# Altium schematic sheet 013_SoCAVInterface.SchDoc(013_SoCAVInterface)
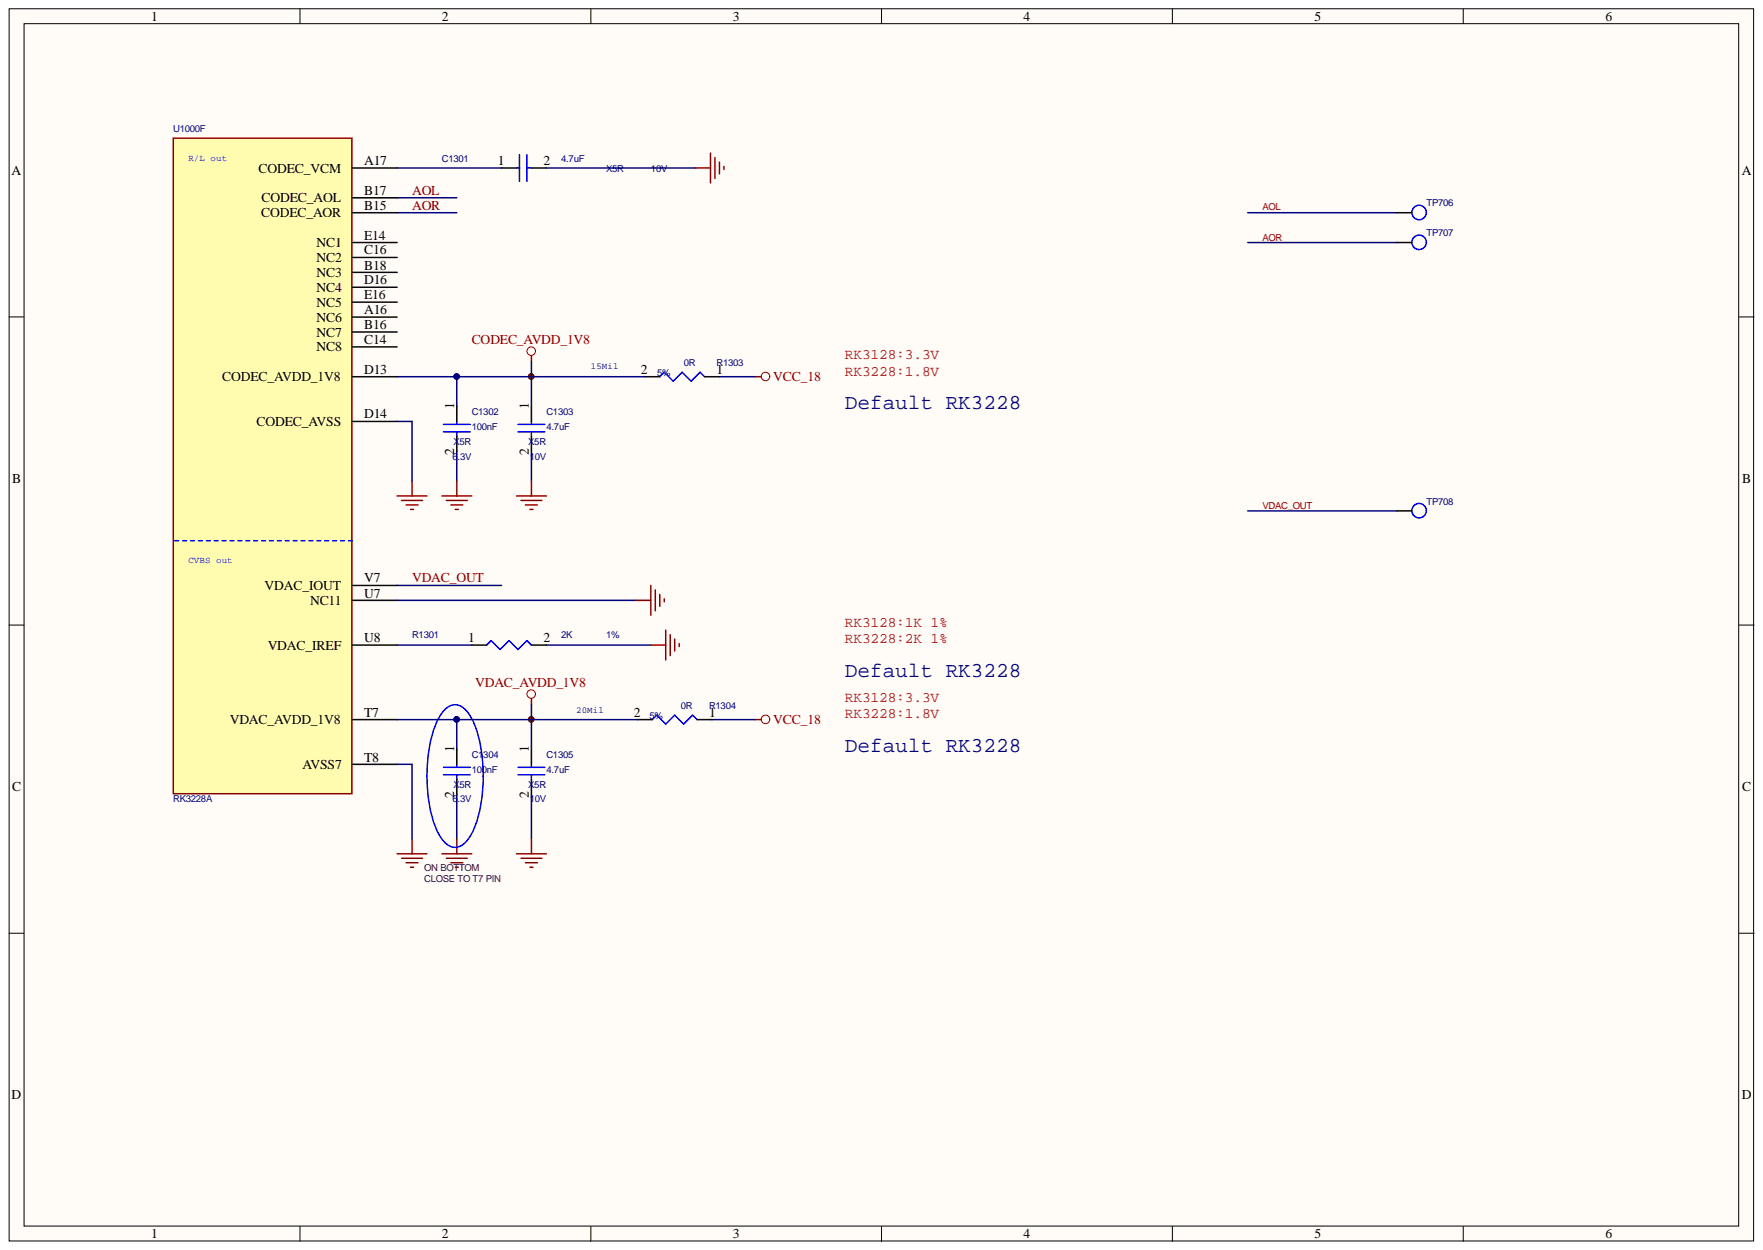
[diagram p0: 013_SoCAVInterface.SchDoc]

## Parts
C1301  4.7uF  [C0603]
C1302  100nF  Voltage: 6.3V  Dielectric: X5R  [C0402]
C1303  4.7uF  Voltage: 10V  Dielectric: X5R  [C0603]
C1304  100nF  Voltage: 6.3V  Dielectric: X5R  [C0402]
C1305  4.7uF  Voltage: 10V  Dielectric: X5R  [C0603]
R1301  2K  [R0402]
R1303  0R  Tolerance: 5%  [R0402]
R1304  0R  Tolerance: 5%  [R0402]
TP706  TP_0.7  [TEST]  (value not drawn)
TP707  TP_0.7  [TEST]  (value not drawn)
TP708  TP_0.7  [TEST]  (value not drawn)
U1000  RK3228A  [BGA316-14X14_0.65]  (part F)

## Nets
CODEC_AVDD_1V8: C1302.1 C1303.1 R1303.2 U1000.D13(CODEC_AVDD_1V8)
GND: C1301.2 C1302.2 C1303.2 C1304.2 C1305.2 R1301.2 U1000.D14(CODEC_AVSS) U1000.T8(AVSS7) U1000.U7(NC11)
VCC_18: R1303.1 R1304.1
VDAC_AVDD_1V8: C1304.1 C1305.1 R1304.2 U1000.T7(VDAC_AVDD_1V8)
AOL: TP706.1 U1000.B17(CODEC_AOL)
AOR: TP707.1 U1000.B15(CODEC_AOR)
VDAC_OUT: TP708.1 U1000.V7(VDAC_IOUT)
NetC1301_1: C1301.1 U1000.A17(CODEC_VCM)
NetR1301_1: R1301.1 U1000.U8(VDAC_IREF)

Not connected: U1000 A16(NC6) B16(NC7) B18(NC3) C14(NC8) C16(NC2) D16(NC4) E14(NC1) E16(NC5)

## Library data of the parts
C1301 C1303 C1305 = CAP NP_Dup5: cap,4.70uF,+/-10%,10V,X5R,C0603; Manufacturer: TDK; Tolerance: 10%; RK PN: C1608X5R1A475K080AC; Priority: A; C_Voltage: 6.3V; Part Type: C0603; Manufacturer PN: C1608X5R1A475K080AC; Component Kind: Standard; Created by: JJJ
  C1301: Voltage: 10V; Dielectric: X5R
C1302 C1304 = CAP NP_Dup5: cap,100.00nF,+/-10%,6.3V,X5R,C0402; Manufacturer: TDK; Tolerance: 10%; RK PN: C1005X5R0J104K050BA; Priority: A; Number: 37; Part Type: C0402; Manufacturer PN: C1005X5R0J104K050BA; Component Kind: Standard; C_Voltage: 6.3V; Created by: JJJ
R1301 = RESISTOR_Dup1: 哥棨슌훡롯量,2K,+/-1%,R0402,1/16W.; Manufacturer: YAGEO; Wattage: 1/16W; Tolerance: 1%; RK PN: RC0402FR-072KL; Priority: A; Part Type: 哥棨슌훡롯量; Manufacturer PN: RC0402FR-072KL; Component Kind: Standard; Created by: YTL
R1303 R1304 = RESISTOR_Dup1: 哥棨슌훡롯量,0R,+/-5%,R0402,1/16W.; Manufacturer: YAGEO; Wattage: 1/16W; RK PN: RC0402JR-070RL; Priority: A; Part Type: 哥棨슌훡롯量; Manufacturer PN: RC0402JR-070RL; Component Kind: Standard; Created by: YTL
TP706-TP708 = TP: Test Point 0.7mm; RK PN: TESTPONIT_0R7; Priority: A; Part Type: TP; Created Date: 20140617; Component Kind: Standard; Created by: CZD
U1000 = DSP_RK3228: ROCKCHIP CPU; Manufacturer: Rockchip (쐉拱匣); RK PN: RK3228; Priority: A; Part Type: DSP; Manufacturer PN: RK3228; Component Kind: Standard; Created by: JJJ
